# Altium schematic sheet Block.SchDoc(Block)
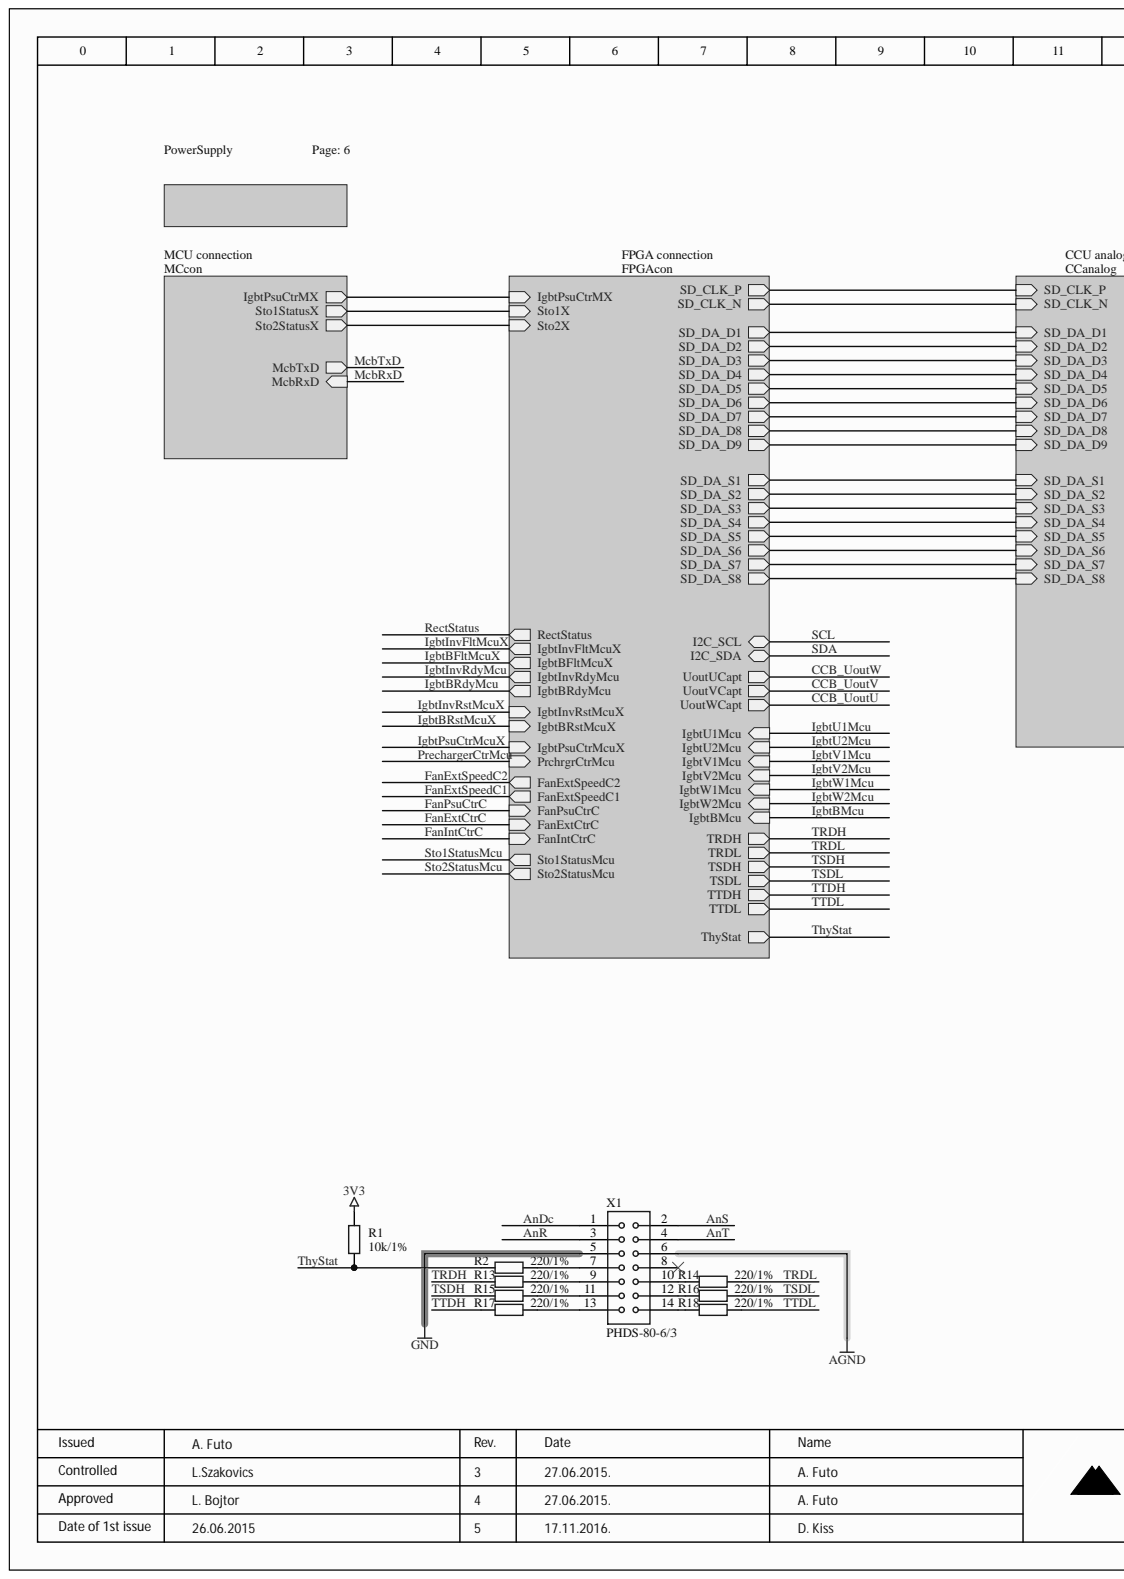
[diagram p0: Block.SchDoc, left]
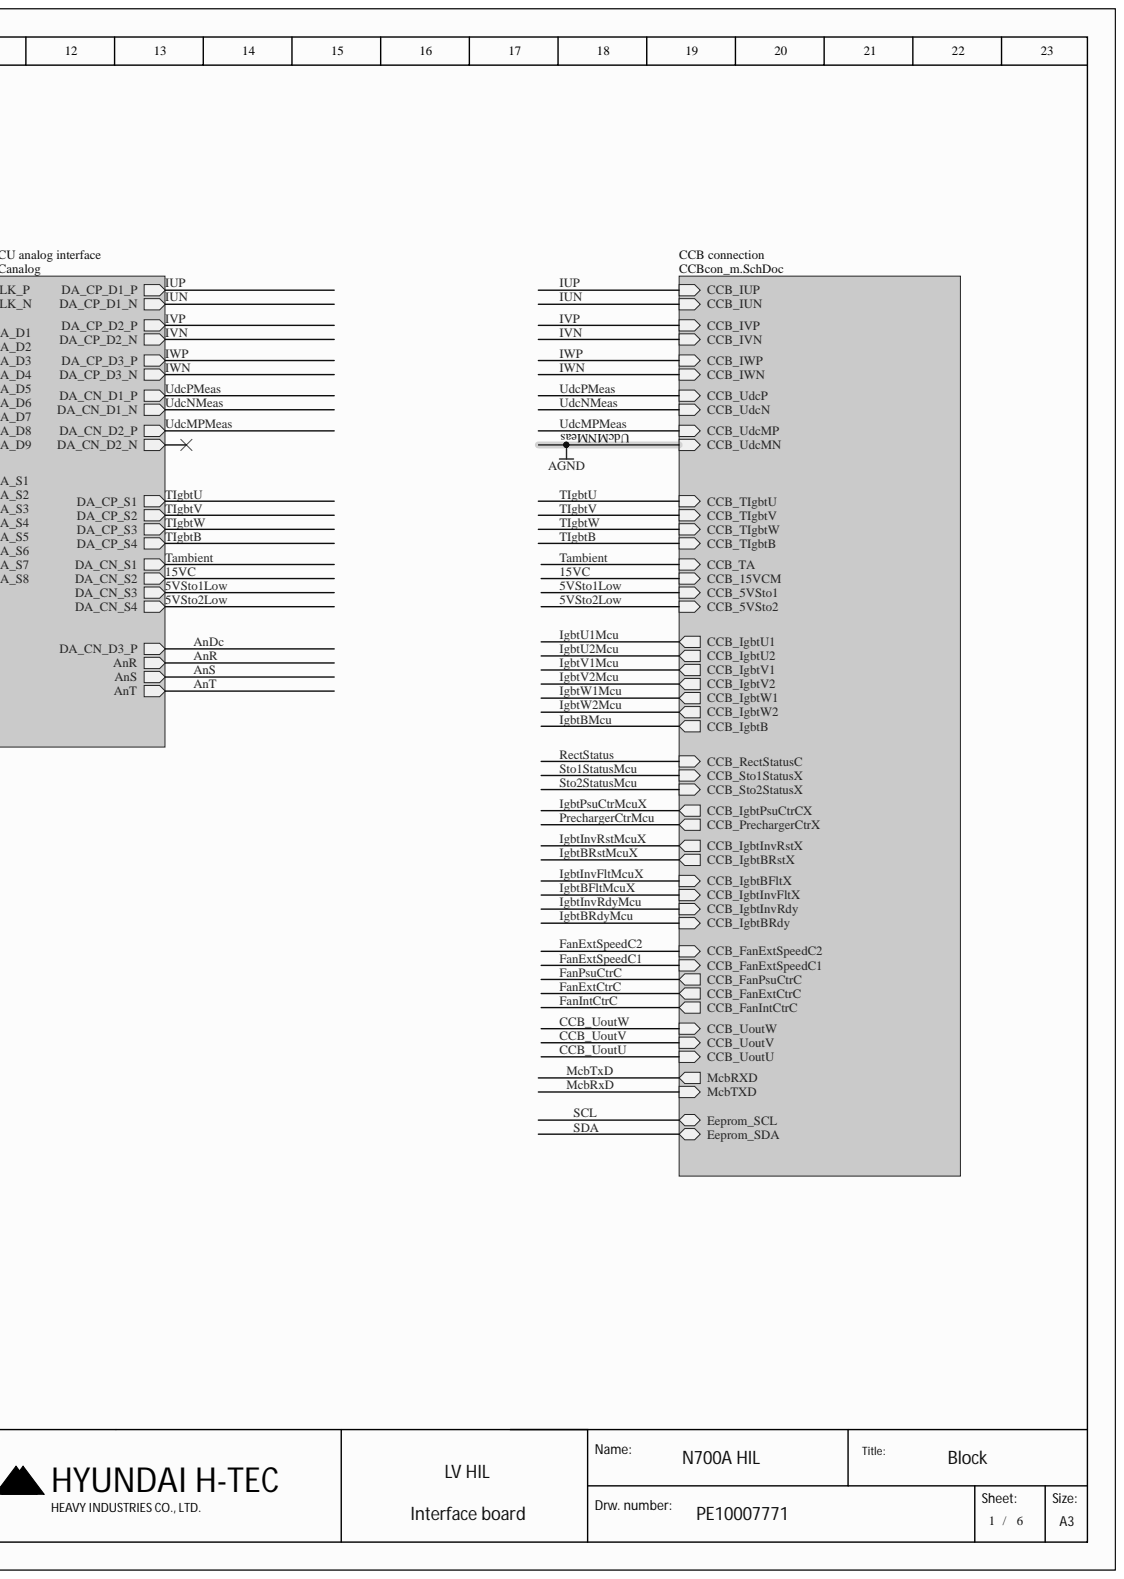
[diagram p1: Block.SchDoc, right]

## Parts
R1  10k/1%  [0603_R]
R2  220/1%  [0603_R]
R13  220/1%  [0603_R]
R14  220/1%  [0603_R]
R15  220/1%  [0603_R]
R16  220/1%  [0603_R]
R17  220/1%  [0603_R]
R18  220/1%  [0603_R]
X1  PHDS-80-6/3  [H14D_V1]

## Nets
3V3: R1.2
AGND: X1.6
GND: X1.5
AnDc: X1.1
AnR: X1.3
AnS: X1.2
AnT: X1.4
ThyStat: R1.1 R2.1
TRDH: R13.1
TRDL: R14.2
TSDH: R15.1
TSDL: R16.2
TTDH: R17.1
TTDL: R18.2
NetR2_2: R2.2 X1.7
NetR13_2: R13.2 X1.9
NetR14_1: R14.1 X1.10
NetR15_2: R15.2 X1.11
NetR16_1: R16.1 X1.12
NetR17_2: R17.2 X1.13
NetR18_1: R18.1 X1.14
NetX1_8: X1.8
5VSto1Low:
5VSto2Low:
15VC:
CCB_UoutU:
CCB_UoutV:
CCB_UoutW:
DA_CN_D2_N:
FanExtCtrC:
FanExtSpeedC1:
FanExtSpeedC2:
FanIntCtrC:
FanPsuCtrC:
IgbtBFltMcuX:
IgbtBMcu:
IgbtBRdyMcu:
IgbtBRstMcuX:
IgbtInvFltMcuX:
IgbtInvRdyMcu:
IgbtInvRstMcuX:
IgbtPsuCtrMcuX:
IgbtPsuCtrMX:
IgbtU1Mcu:
IgbtU2Mcu:
IgbtV1Mcu:
IgbtV2Mcu:
IgbtW1Mcu:
IgbtW2Mcu:
IUN:
IUP:
IVN:
IVP:
IWN:
IWP:
McbRxD:
McbTxD:
PrechargerCtrMcu:
RectStatus:
SCL:
SD_CLK_N:
SD_CLK_P:
SD_DA_D1:
SD_DA_D2:
SD_DA_D3:
SD_DA_D4:
SD_DA_D5:
SD_DA_D6:
SD_DA_D7:
SD_DA_D8:
SD_DA_D9:
SD_DA_S1:
SD_DA_S2:
SD_DA_S3:
SD_DA_S4:
SD_DA_S5:
SD_DA_S6:
SD_DA_S7:
SD_DA_S8:
SDA:
Sto1StatusMcu:
Sto1X:
Sto2StatusMcu:
Sto2X:
Tambient:
TIgbtB:
TIgbtU:
TIgbtV:
TIgbtW:
UdcMPMeas:
UdcNMeas:
UdcPMeas:

## Library data of the parts
R1 = 1: Resistor; part_numberKorea: WR06X1002FTL; part_numberEU: RC0603JR-0710KL; ManufacturerKorea: Walsin; ManufacturerEU: Yageo; K_PartNum: 1001; Voltage: 50V; Value: 10k; Tolerance: 1%; Power: 0.1W; K_PN_Note: SMD Resistor; package_type: SMD; Library Name: AltiumLibrary.SVNDbLib; DesignItemID: 1; Database Table Name: resistor; ComponentLink2Description: DatasheetKorea; ComponentLink1Description: DatasheetEU; Component Kind: Standard; component_type: Resistor; ComponentLink2URL: u:\PCB_Designs\_AltiumLibrary\Passive\pdf\WR_series_Resistor.pdf; ComponentLink1URL: u:\PCB_Designs\_AltiumLibrary\Passive\pdf\Yageo-RC0603_51_RoHS_L_4.pdf
R2 R13-R18 = 16: Resistor; part_numberKorea: WR06X2200FTL; part_numberEU: RC0603FR-07220RL; ManufacturerKorea: Walsin; ManufacturerEU: Yageo; K_PartNum: 1001; Voltage: 50V; Value: 220; Tolerance: 1%; Power: 0.1W; K_PN_Note: SMD Resistor; package_type: SMD; Library Name: AltiumLibrary.SVNDbLib; DesignItemID: 16; Database Table Name: resistor; ComponentLink2Description: DatasheetKorea; ComponentLink1Description: DatasheetEU; Component Kind: Standard; component_type: Resistor; ComponentLink2URL: u:\PCB_Designs\_AltiumLibrary\Passive\pdf\WR_series_Resistor.pdf; ComponentLink1URL: u:\PCB_Designs\_AltiumLibrary\Passive\pdf\Yageo-RC0603_51_RoHS_L_4.pdf
X1 = 474: Connector; ManufacturerKorea: T&T Connectivity; ManufacturerEU: T&T Connection System; K_PartNum: 2011; Note: Pin Header; K_PN_Note: Thru Connector; Factor: 0.175; part_numberKorea: PHDS-80-6/3; part_numberEU: PHDS-80-6/3; package_type: THRU; Library Name: AltiumLibrary.SVNDbLib; DesignItemID: 474; Database Table Name: connector; ComponentLink2Description: DatasheetKorea; ComponentLink1Description: DatasheetEU; Component Kind: Standard; component_type: Connector; ComponentLink2URL: u:\PCB_Designs\_AltiumLibrary\Connector\pdf\(t-t)_phds-xx-6-3.pdf; ComponentLink1URL: u:\PCB_Designs\_AltiumLibrary\Connector\pdf\(t-t)_phds-xx-6-3.pdf
